# Altium schematic sheet DL-Scint-Top.SchDoc(DL-Scint-Top)
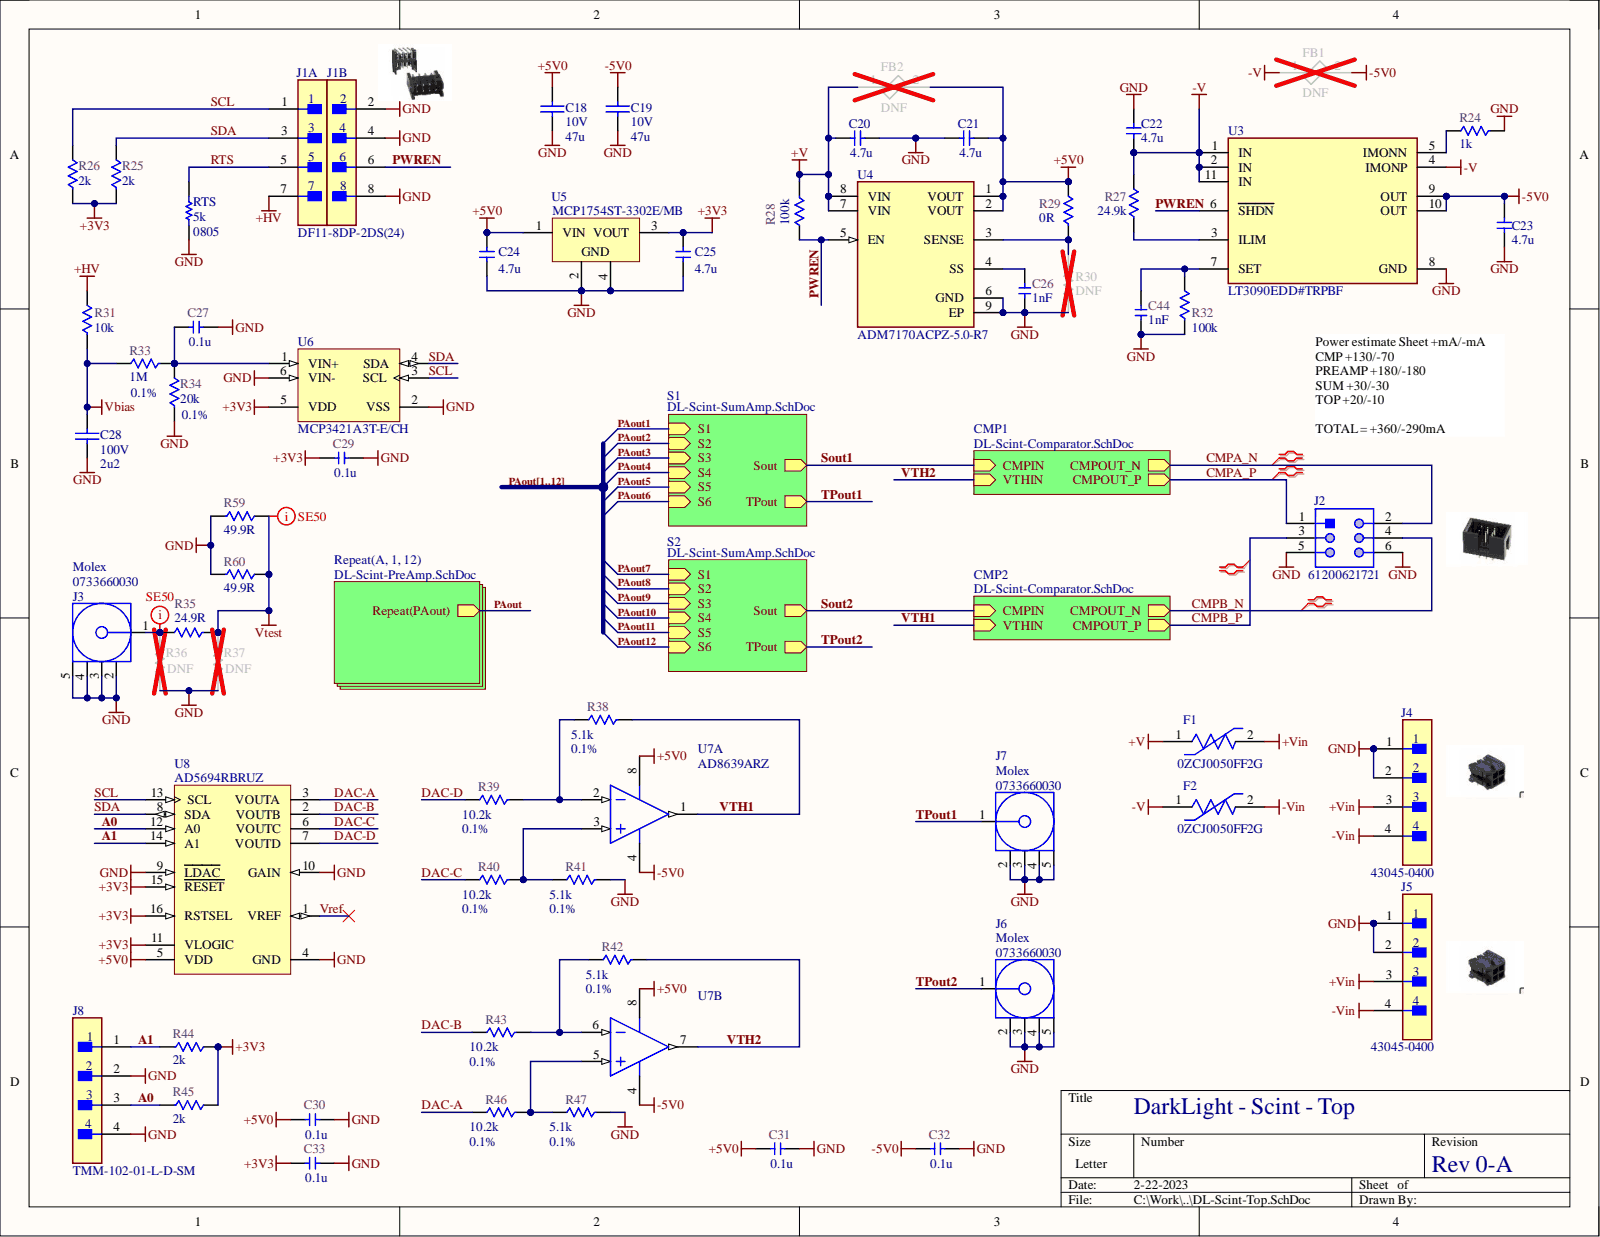
[diagram p0: DL-Scint-Top.SchDoc]

## Parts
C18  value 47u  Voltage Rated: 10V  [1210_C]
C19  value 47u  Voltage Rated: 10V  [1210_C]
C20  value 4.7u  [CAP_0805]
C21  value 4.7u  [CAP_0805]
C22  value 4.7u  [CAP_0805]
C23  value 4.7u  [CAP_0805]
C24  value 4.7u  [CAP_0805]
C25  value 4.7u  [CAP_0805]
C26  value 1nF  [0603 Cap]
C27  value 0.1u  [0603 Cap]
C28  value 2u2  Voltage Rated: 100V  [1210_C]
C29  value 0.1u  [0603 Cap]
C30  value 0.1u  [0603 Cap]
C31  value 0.1u  [0603 Cap]
C32  value 0.1u  [0603 Cap]
C33  value 0.1u  [0603 Cap]
C44  value 1nF  [0603 Cap]
F1  Part Number: 0ZCJ0050FF2G  [FP-0ZCJ0050FF2G-MFG]
F2  Part Number: 0ZCJ0050FF2G  [FP-0ZCJ0050FF2G-MFG]
FB1  DNF  [FP-BLM21-0_2-t0_85-e0_5-MFG]
FB2  DNF  [FP-BLM21-0_2-t0_85-e0_5-MFG]
J1  Part Number: DF11-8DP-2DS(24)  [FP-DF11-8DP-2DS_24-MFG]  (parts A,B)
J2  (no value)  [61200621721]
J3  Part Number: 0733660030  [733660030]
J4  Package: 43045-0400  [FP-43045-0400-MFG]
J5  Package: 43045-0400  [FP-43045-0400-MFG]
J6  Manufacturer: Molex  Part Number: 0733660030  [733660030]
J7  Manufacturer: Molex  Part Number: 0733660030  [733660030]
J8  Part Number: TMM-102-01-L-D-SM  [FP-TMM-102-01-L-D-SM-MFG]
R24  value 1k  [0603 RES]
R25  value 2k  [0603 RES]
R26  value 2k  [0603 RES]
R27  value 24.9k  [0603 RES]
R28  value 100k  [0603 RES]
R29  value 0R  [0603 RES]
R30  value DNF  [0603 RES]
R31  value 10k  [0603 RES]
R32  value 100k  [0603 RES]
R33  value 1M  [0603 RES]
R34  value 20k  Tolerance: 0.1%  [0603 RES]
R35  value 24.9R  [0603 RES]
R36  value DNF  [0603 RES]
R37  value DNF  [0603 RES]
R38  value 5.1k  [0603 RES]
R39  value 10.2k  Tolerance: 0.1%  [0603 RES]
R40  value 10.2k  Tolerance: 0.1%  [0603 RES]
R41  value 5.1k  Tolerance: 0.1%  [0603 RES]
R42  value 5.1k  Tolerance: 0.1%  [0603 RES]
R43  value 10.2k  Tolerance: 0.1%  [0603 RES]
R44  value 2k  [0603 RES]
R45  value 2k  [0603 RES]
R46  value 10.2k  Tolerance: 0.1%  [0603 RES]
R47  value 5.1k  Tolerance: 0.1%  [0603 RES]
R59  value 49.9R  [0603 RES]
R60  value 49.9R  [0603 RES]
RTS  value 5k  Package: 0805  [0805_R]
U3  Part Number: LT3090EDD#TRPBF  [FP-DD-10-05-08-1699-MFG]
U4  Part Number: ADM7170ACPZ-5.0-R7  [FP-CP-8-21-IPC_C]
U5  Part Number: MCP1754ST-3302E/MB  [MCHP-SOT-89-MB3_L]
U6  Part Number: MCP3421A3T-E/CH  [SOT-23-CH6_N]
U7  Part Number: AD8639ARZ  [ADI-R-8_N]  (parts A,B)
U8  Part Number: AD5694RBRUZ  [RU-16_L]

## Nets
+3V3: C25.1 C29.2 C33.2 R25.1 R26.1 R44.2 R45.2 U5.3(VOUT) U6.5(VDD) U8.11(VLOGIC) U8.15(RESET) U8.16(RSTSEL)
+5V0: C18.2 C21.1 C24.1 C30.2 C31.2 FB2.2 R29.2 U4.1(VOUT) U4.2(VOUT) U5.1(VIN) U7.8 U8.5(VDD)
+HV: J1.7 R31.2
+V: C20.1 F1.1 FB2.1 R28.1 U4.7(VIN) U4.8(VIN)
+Vin: F1.2 J4.3 J5.3
-5V0: C19.2 C23.1 C32.2 FB1.2 U3.9(OUT) U3.10(OUT) U7.4
-V: C22.1 F2.1 FB1.1 R27.2 U3.1(IN) U3.2(IN) U3.4(IMONP) U3.11(IN)
-Vin: F2.2 J4.4 J5.4
GND: C18.1 C19.1 C20.2 C21.2 C22.2 C23.2 C24.2 C25.2 C26.1 C27.1 C28.1 C29.1 C30.1 C31.1 C32.1 C33.1 C44.1 J1.2 J1.4 J1.8 J2.5 J2.6 J3.2 J3.3 J3.4 J3.5 J4.1 J4.2 J5.1 J5.2 J6.2 J6.3 J6.4 J6.5 J7.2 J7.3 J7.4 J7.5 J8.2 J8.4 R24.1 R30.1 R32.1 R34.1 R36.1 R37.2 R41.1 R47.1 R59.1 R60.1 RTS.1 U3.8(GND) U4.6(GND) U4.9(EP) U5.2 U5.4 U6.2(VSS) U6.6(VIN-) U8.4(GND) U8.9(LDAC) U8.10(GAIN)
Vbias: C28.2 R31.1 R33.2
Vtest: R35.1 R37.1 R59.2 R60.2
A0: J8.3 R45.1 U8.12(A0)
A1: J8.1 R44.1 U8.14(A1)
CMPA_N: J2.2
CMPA_P: J2.1
CMPB_N: J2.4
CMPB_P: J2.3
DAC-A: R46.2 U8.3(VOUTA)
DAC-B: R43.2 U8.2(VOUTB)
DAC-C: R40.2 U8.6(VOUTC)
DAC-D: R39.2 U8.7(VOUTD)
PWREN: J1.6 R28.2 U3.6(SHDN) U4.5(EN)
RTS: J1.5 RTS.2
SCL: J1.1 R26.2 U6.3(SCL) U8.13(SCL)
SDA: J1.3 R25.2 U6.4(SDA) U8.8(SDA)
TPout1: J7.1
TPout2: J6.1
Vref: U8.1(VREF)
VTH1: R38.1 U7.1
VTH2: R42.1 U7.7
NetC26_2: C26.2 U4.4(SS)
NetC27_2: C27.2 R33.1 R34.2 U6.1(VIN+)
NetC44_2: C44.2 R32.2 U3.7(SET)
NetJ3_1: J3.1 R35.2 R36.2
NetR24_2: R24.2 U3.5(IMONN)
NetR27_1: R27.1 U3.3(ILIM)
NetR29_1: R29.1 R30.2 U4.3(SENSE)
NetR38_2: R38.2 R39.1 U7.2
NetR40_1: R40.1 R41.2 U7.3
NetR42_2: R42.2 R43.1 U7.6
NetR46_1: R46.1 R47.2 U7.5
PAout1:  [also labelled PAout]
PAout2:  [also labelled PAout]
PAout3:  [also labelled PAout]
PAout4:  [also labelled PAout]
PAout5:  [also labelled PAout]
PAout6:  [also labelled PAout]
PAout7:  [also labelled PAout]
PAout8:  [also labelled PAout]
PAout9:  [also labelled PAout]
PAout10:  [also labelled PAout]
PAout11:  [also labelled PAout]
PAout12:  [also labelled PAout]
Sout1:
Sout2:

## Library data of the parts
C18 C19 = Cap_1210_2: CAP CER 47UF 10V X7R 1210; Manufacturer: Taiyo; Part Number: LMK325B7476MM-PR; Packge: 1210; Tolerance: 20%; Component Kind: Standard; Library Name: SiPM-FE-REV1.SchLib; Temp. Coef.: X7R
C20-C25 = CAP_0805: CAP CER 4.7UF 16V X7R 0805; Manufacturer: Taiyo; Part Number: EMK212B7475KG-T; Component Kind: Standard; Package Size: 0805; Library Name: Ext_Scint_Lib.SchLib
C26 C44 = CAP-SMALL: CAP CER 1nF 50V X7R 0603; Manufacturer: TDK; Part Number: CGA3E2X7R1H102K080AA; Case-EIA: 0603; Case-Metric: 1608; Tolerance: 10%; Component Kind: Standard; Rated Voltage: 50V; Library Name: Ext_Scint_Lib.SchLib
C27 C29-C33 = CAP-SMALL: CAP CER 0.1UF 50V X7R 0603; Manufacturer: TDK; Part Number: CGA3E2X7R1H104K080AA; Case-EIA: 0603; Case-Metric: 1608; Tolerance: 10%; Component Kind: Standard; Rated Voltage: 50V; Library Name: Ext_Scint_Lib.SchLib
C28 = Cap_1210_2: CAP CER 2.2UF 100V X7R 1210; Manufacturer: Kyocera; Part Number: 12101C225KAZ2A; Packge: 1210; Tolerance: 10%; Component Kind: Standard; Library Name: SiPM-FE-REV1.SchLib; Temp. Coef.: X7R
F1 F2 = CMP-16043-000004-1: PTC RESET FUSE 8V 500MA 1206; Max Current Rating: 100A; Time to Trip: 0.1s; Trip Current: 1A; Case Code (Imperial): 1206; Case Code (Metric): 3216; Case/Package: 1206; Component Kind: Standard; Contact Plating: Tin; Hold Current: 500mA; Lead Free: Lead Free; Library Name: Altium Content Vault; Max Operating Temperature: 85°C; Min Operating Temperature: -40°C; Mount: Surface Mount; Package Quantity: 1; Packaging: Tape and Reel
FB1 FB2 = CMP-0686-00622-4: Chip Ferrite Bead, 0805, 22Ω @ 100MHz, 0.009Ω, 25%, 6A; Manufacturer Series: BLM21P; DC Resistance (DCR): 9mR; Impedance: 22R; Material: Steel; Number of Circuits: 1; Resistance: 10mR; Shielding: Unshielded; Case Code (Imperial): 0805; Case Code (Metric): 2012; Case/Package: 0805; Component Kind: Standard; Core Material: Ferrite; Current Rating: 6A; DC Current: 6A; DC Resistance-Max: 9mR; Depth: 1.25mm; Height: 0.85mm; Lead Free: Lead Free; Length: 2mm; Library Name: Altium Content Vault; Max Current Rating: 6A; Max DC Current: 6A; Max Operating Temperature: 125°C; Min Operating Temperature: -55°C; Number of Functions: 1; Number of Lines: 1; Number of Pins: 2; Package Quantity: 1; Packaging: Tape and Reel; Radiation Hardening: No; REACH SVHC: No SVHC; RoHS Compliant: Yes; Series: BLM21P; Terminal Finish: Tin; Termination: SMD/SMT; Test Frequency: 100MHz; Tolerance: 25%; Weight: 0.000194oz; Width: 1.25mm
J1 = CMP-11610-000029-1: CONN HEADER R/A 8POS 2MM; Connector Type: Male Pin; Contact Resistance: 30mR; Current Rating: 2A; Gender: Male; Number of Contacts: 8; Row Spacing: 0.079inch; Voltage Rating: 250V; Color: Black; Component Kind: Standard; Contact Gender: Male; Contact Material: Brass; Contact Mating Length: 0.118inch; Contact Plating: Tin; Contact Type: Male Pin; Depth: 10mm; Fastening Type: Detent; Flammability Rating: UL94 V-0; Housing Color: Black; Housing Material: Polyamide; Lead Free: Lead Free; Length: 10mm; Library Name: Altium Content Vault; Max Current Rating: 2A; Max Operating Temperature: 85°C; Min Operating Temperature: -30°C; Mount: Through Hole; Number of Positions: 8; Number of Rows: 2; Orientation: Right Angle; Package Quantity: 48; Packaging: Rail/Tube; Pitch: 2mm; Radiation Hardening: No; REACH SVHC: No SVHC; RoHS Compliant: Yes; Series: DF11; Termination: Solder
J2 = CMP-1502-00019-1: CONN HEADER R/A 6POS 2.54MM; Manufacturer: Wurth Elektronik; Contact Resistance: 20mOhm; Gender: Male; Pin Number: 6; Withstanding Voltage: 500VAC; Working Voltage: 250VAC; Component Kind: Standard; Datasheet URL: https://katalog.we-online.de/em/datasheet/6120xx21721.pdf; Library Name: Altium Content Vault; Manufacturer URL: http://www.we-online.de; Mounting Technology: THT; Operating Temperature: -40to125degC; Orientation: Angled; Package Reference: 61200621721; Packaging: Tray; Part Number: 61200621721; Pitch: 2.54mm; Published Date: August2018; Publisher: WurthElektronik
J3 = CON-RF-01: CONN JACK R/A 50 OHM PCB; Manufacturer: Molex; Component Kind: Standard; Library Name: SiPM-FE-REV1.SchLib
J4 J5 = CMP-04776-000002-1: CONN HEADER R/A 4POS 3MM; Manufacturer: Molex; Conn Gender: Male; Conn Orientation: Right Angle; Current Rating: 8.5A; Is Female: yes; Number Of Contacts: 4; Voltage Rating: 600V; Automotive: No; Category: Conn; Component Kind: Standard; ComponentLink2Description: Datasheet; ComponentLink2URL: https://www.molex.com/webdocs/datasheets/pdf/en-us/0430450400_PCB_HEADERS.pdf; Contact Material: Tin,Nickel; Device Class L1: Connectors; Device Class L2: Headers and Wire Housings; Device Class L3: unset; Digikey Description: CONN HEADER R/A 4POS 3MM; Footprint Url: https://www.molex.com/pdm_docs/sd/430450400_sd.pdf; Height: 9.27mm; Lead Free: Yes; Library Name: Altium Content Vault; Number Of Rows: 2; Octopart: https://octopart.com/43045-0400-molex-287063; Part Number: 43045-0400; Pitch: 3mm; RoHS: TRUE; Temperature: -40°C to +105°C; Temperature Range High: +105°C; Temperature Range Low: -40°C
J6 J7 = CON-RF-01: CONN JACK R/A 50 OHM PCB; Component Kind: Standard; Library Name: SiPM-FE-REV1.SchLib
J8 = CMP-02766-001391-1: CONN HEADER SMD 4POS 2MM; Gender: Male; Max Current Rating: 3.9A; Max Voltage Rating (AC): 500V; Number of Contacts: 4; Component Kind: Standard; Contact Material: Bronze; Contact Plating: Gold; Depth: 3.94mm; Housing Color: Black; Housing Material: Polymer; Lead Free: Lead Free; Length: 4mm; Library Name: Altium Content Vault; Max Operating Temperature: 125°C; Min Operating Temperature: -55°C; Number of Rows: 2; Orientation: Straight; Packaging: Bulk; Pitch: 2mm; Radiation Hardening: No; REACH SVHC: No SVHC; RoHS Compliant: Yes; Termination: Solder
R24 = RES-SMALL: RES 1K OHM 1% 1/10W 0603; Manufacturer: Yageo; Part Number: RC0603FR-101KL; Component Kind: Standard; Package: 0603; Tolerance: 1%; Library Name: Ext_Scint_Lib.SchLib
R25 R26 R44 R45 = RES-SMALL: RES 2K OHM 1% 1/10W 0603; Manufacturer: Yageo; Part Number: AC0603FR-072KL; Component Kind: Standard; Package: 0603; Tolerance: 1%; Library Name: Ext_Scint_Lib.SchLib
R27 = RES-SMALL: RES 24.9K OHM 1% 1/10W 0603; Manufacturer: Yageo; Part Number: RC0603FR-1024K9L; Component Kind: Standard; Package: 0603; Tolerance: 1%; Library Name: Ext_Scint_Lib.SchLib
R28 R32 = RES-SMALL: RES 100K OHM 1% 1/10W 0603; Manufacturer: Yageo; Part Number: RC0603FR-07100KL; Component Kind: Standard; Package: 0603; Tolerance: 1%; Library Name: Ext_Scint_Lib.SchLib
R29 = RES-SMALL: RES 0 OHM 1% 1/10W 0603; Manufacturer: Yageo; Part Number: RC0603FR-070RL; Component Kind: Standard; Package: 0603; Tolerance: 1%; Library Name: Ext_Scint_Lib.SchLib
R30 = RES-SMALL: RES  OHM 1% 1/10W 0603; Manufacturer: Yageo; Component Kind: Standard; Package: 0603; Tolerance: 1%; Library Name: Ext_Scint_Lib.SchLib
R31 = RES-SMALL: RES 10K OHM 1% 1/10W 0603; Manufacturer: Yageo; Part Number: AC0603FR-1010KL; Case-EIA: 0603; Case-Metric: 1608; Component Kind: Standard; Tolerance: 1%; Library Name: Ext_Scint_Lib.SchLib
R33 = RES-SMALL: RES 1M  OHM 0.1% 1/10W 0603; Manufacturer: Yageo; Part Number: RT0603BRD071ML; Component Kind: Standard; Package: 0603; Tolerance: 0.1%; Library Name: Ext_Scint_Lib.SchLib
R34 = RES-SMALL: RES 20K OHM 0.1% 1/10W 0603; Manufacturer: Panasonic; Part Number: ERA-3AEB203V; Component Kind: Standard; Package: 0603; Library Name: Ext_Scint_Lib.SchLib
R35 = RES-SMALL: RES 24.9 OHM 1% 1/10W 0603; Manufacturer: Yageo; Part Number: RC0603FR-0724R9L; Case-EIA: 0603; Case-Metric: 1608; Component Kind: Standard; Tolerance: 1%; Library Name: Ext_Scint_Lib.SchLib
R36 R37 = RES-SMALL: RES  OHM 1% 1/10W 0603; Manufacturer: Yageo; Case-EIA: 0603; Case-Metric: 1608; Component Kind: Standard; Tolerance: 1%; Library Name: Ext_Scint_Lib.SchLib
R38 R41 R42 R47 = RES-SMALL: RES 5.1K OHM 0.1% 1/10W 0603; Manufacturer: Panasonic; Part Number: ERA-3AEB512V; Case-EIA: 0603; Case-Metric: 1608; Component Kind: Standard; Library Name: Ext_Scint_Lib.SchLib
  R38: Tolerance: 0.1%
R39 R40 R43 R46 = RES-SMALL: RES 10.2K OHM 0.1% 1/10W 0603; Manufacturer: Stackpole; Part Number: RNCF0603BKE10K2; Case-EIA: 0603; Case-Metric: 1608; Component Kind: Standard; Library Name: Ext_Scint_Lib.SchLib
R59 R60 = RES-SMALL: RES  OHM 1% 1/10W 0603; Manufacturer: Yageo; Part Number: RC0603FR-0749R9L; Case-EIA: 0603; Case-Metric: 1608; Component Kind: Standard; Tolerance: 1%; Library Name: Ext_Scint_Lib.SchLib
RTS = Res_0805_1: THERMISTOR PTC 5K OHM 1% 0805; Manufacturer: Vishay; Part Number: TFPT0805L5001FV; Component Kind: Standard; Library Name: SiPM-FE-REV1.SchLib; Tolerance: 1%
U3 = CMP-09138-000235-1: IC REG LIN NEG ADJ 600MA 10DFN; Dropout Voltage: 300mV; Number of Regulators: 1; Output Voltage: -32V; Case/Package: DFN; Component Kind: Standard; Library Name: Altium Content Vault; Max Operating Temperature: 125°C; Min Operating Temperature: -40°C; Mount: Surface Mount; Number of Pins: 10; Output Current: 600mA; Package Quantity: 2500; Packaging: Tape and Reel; RoHS Compliant: Yes; Series: -
U4 = CMP-09138-000012-1: IC REG LINEAR 5V 500MA 8LFCSP; Manufacturer: Analog Devices; Dropout Voltage: 42mV; Max Junction Temp: +125°C; Max Supply Voltage: 6.5V; Min Supply Voltage: 2.3V; Nominal Supply Current: 0.7-3mA; Number Of Outputs: 1; Output Type: Fixed; Standoff Height: 0.02mm; Automotive: No; Category: IC; Component Kind: Standard; ComponentLink2Description: Datasheet; ComponentLink2URL: https://www.analog.com/media/en/technical-documentation/data-sheets/ADM7170.pdf; Device Class L1: Integrated Circuits (ICs); Device Class L2: Power Management ICs; Device Class L3: Voltage Regulators - Linear; Digikey Description: IC REG LINEAR 5V 500MA 8LFCSP; Height: 0.8mm; Ipc Land Pattern Name: DFN300X300X75-8; Lead Free: Yes; Library Name: Altium Content Vault; Mouser Description: LDO Regulator Pos 5V 0.5A 8-Pin LFCSP EP T/R; Octopart: https://octopart.com/adm7170acpz-5.0-r7-analog+devices-49227326; Output Current: 500mA; Output Voltage: 5V; Package: CP-8-21; RoHS: TRUE; Temperature: -40°C to +125°C; Temperature Range High: +125°C; Temperature Range Low: -40°C
U5 = CMP-0136-01161-1: 150 mA, 16 V, High Performance LDO, -40 to 125 degC, 3-pin SOT-89 (MB3), Tape and Reel; Manufacturer: Microchip; Component Kind: Standard; ComponentLink1Description: Manufacturer URL; ComponentLink1URL: http://www.microchip.com; ComponentLink2Description: Datasheet; ComponentLink2URL: http://ww1.microchip.com/downloads/en/DeviceDoc/22276A.pdf; ComponentLink3Description: Package Specification; ComponentLink3URL: http://www.microchip.com/stellent/groups/techpub_sg/documents/packagingspec/en012702.pdf; ComponentLink4Description: Product URL; ComponentLink4URL: http://www.microchip.com/MCP1754S; DatasheetVersion: Rev. A; Library Name: Altium Content Vault; Mounting Technology: Surface Mount; PackageDescription: 3-Lead Plastic Small Outline Transistor Header, 4.5 x 2.45 mm Body, 1.5 mm Pitch; PackageReference: SOT-89-MB3; PackageVersion: C04-029B
U6 = CMP-0188-00756-2: 18-Bit Analog-to-Digital Converter with I2C Interface and On-Board Reference, 6-Pin SOT-23, Extended Temperature, Tape and Reel; Code_IPC: SOT26A-6; Component Kind: Standard; ComponentLink1Description: Manufacturer URL; ComponentLink1URL: http://www.microchip.com/; ComponentLink2Description: Datasheet; ComponentLink2URL: http://ww1.microchip.com/downloads/en/DeviceDoc/22003e.pdf; ComponentLink3Description: Package Specification; ComponentLink3URL: http://www.microchip.com/stellent/groups/techpub_sg/documents/packagingspec/en012702.pdf; DatasheetVersion: revE, Aug-2009; Library Name: Altium Content Vault; PackageDescription: 6-Lead Plastic Small Outline Transistor (CH) [SOT-23]; PackageReference: SOT-23-CH6; PackageVersion: revBB, Aug-2009; RevisionNote: URLs added.
U7 = CMP-0384-00879-1: Double Channel Rail-to-Rail Operational Amplifier, 1.5 MHz BW, 2 V/us SR, Industrial, 8-pin SOIC (R-8), Tube; Generic Part Number: AD8639; Manufacturer: Analog Devices; Amplifiers Per Package: 2; Automotive Qualified: Yes; Input Bias Current: 1pA; Input Offset Voltage: 3uV; Iq/Amp: 1.5mA; Slew Rate: 2V/us; Small Signal Bandwidth: 1.5MHz; V Span Max: 16V; V Span Min: 5V; Code_JEDEC: MS-012-AA; Component Kind: Standard; ComponentLink1Description: Manufacturer URL; ComponentLink1URL: http://www.analog.com/en/index.html; ComponentLink2Description: Datasheet; ComponentLink2URL: http://www.analog.com/static/imported-files/data_sheets/AD8638_8639.pdf; ComponentLink3Description: Package Specification; ComponentLink3URL: http://www.analog.com/static/imported-files/packages/PKG_PDF/SOIC_Narrow%20(R)/R_8.pdf; DatasheetVersion: Rev. F; Library Name: Altium Content Vault; Mounting Technology: Surface Mount; PackageDescription: 8-Pin Standard Small Outline Package, Body 3.9 x 4.9 mm, Pitch 1.27 mm; PackageReference: R-8; PackageVersion: 060506-A
U8 = CMP-0318-01677-2: Quad 12-Bit nanoDAC+ with I2C Interface and 2 ppm/degC On-Chip Reference, -40 to +105 degC, RU-16, Pb-Free, Tube; Generic Part Number: AD5694R; Manufacturer: Analog Devices; # DAC Outputs: 4; Max V+: 5.5V; Resolution (Bits): 12; Settling Time: 5Âµs; Single Supply: Yes; Code_JEDEC: MO-153-AB; Component Kind: Standard; ComponentLink1Description: Manufacturer URL; ComponentLink1URL: http://www.analog.com/en/index.html; ComponentLink2Description: Datasheet; ComponentLink2URL: http://www.analog.com/static/imported-files/data_sheets/AD5696R_AD5695R_AD5694R.pdf; ComponentLink3Description: Package Specification; ComponentLink3URL: http://www.analog.com/static/imported-files/packages/36244347913743854010197452789RU16.pdf; ComponentLink4Description: Product URL; ComponentLink4URL: http://www.analog.com/en/digital-to-analog-converters/da-converters/ad5694r/products/product.html; DatasheetVersion: Rev.0; Library Name: Altium Content Vault; Mounting Technology: Surface Mount; PackageDescription: 16-Lead Thin Shrink Small Outline Package [TSSOP]; PackageReference: RU-16; PackageVersion: Nov-2002; Packing: Tube; RevisionNote: Component URL fixed; RoHS: Y
